# Altium schematic sheet Sch_Mcu.SchDoc
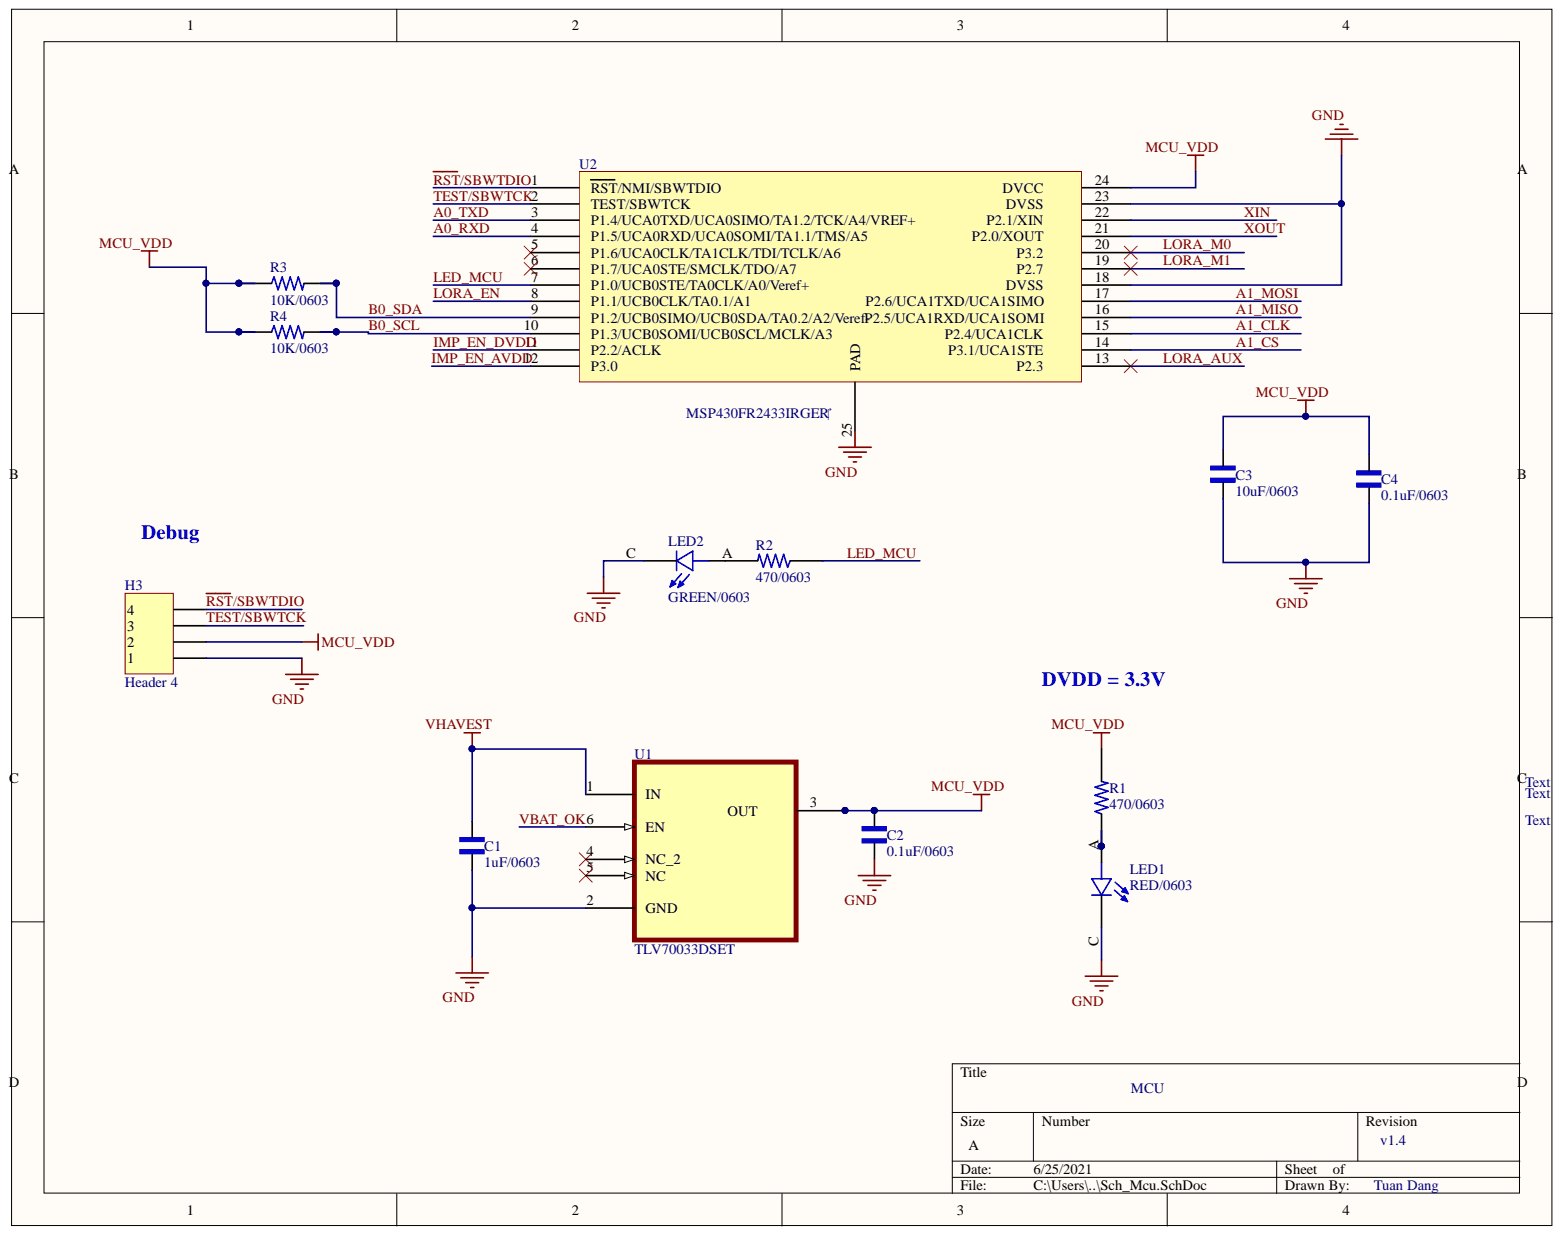
[diagram p0: Sch_Mcu.SchDoc]

## Parts
C1  1uF/0603  [CAP-0603]
C2  0.1uF/0603  [CAP-0603]
C3  10uF/0603  [CAP-0603]
C4  0.1uF/0603  [CAP-0603]
H3  Header 4  [HDR1X4]
LED1  RED/0603  [LED-0603]
LED2  GREEN/0603  [LED-0603]
R1  470/0603  [RES-0603]
R2  470/0603  [RES-0603]
R3  10K/0603  [RES-0603]
R4  10K/0603  [RES-0603]
U1  TLV70033DSET  [6WSON]
U2  MSP430FR2433IRGER‎  [VQFN-24]

## Nets
GND: C1.2 C2.1 C3.2 C4.2 H3.1 LED1.C LED2.C U1.2(GND) U2.18 U2.23 U2.25(PAD)
MCU_VDD: C2.2 C3.1 C4.1 H3.2 R1.2 R3.1 R4.1 U1.3 U2.24
VHAVEST: C1.1 U1.1(IN)
A0_RXD: U2.4(P1.5/UCA0RXD/UCA0SOMI/TA1.1/TMS/A5)
A0_TXD: U2.3(P1.4/UCA0TXD/UCA0SIMO/TA1.2/TCK/A4/VREF+)
A1_CLK: U2.15
A1_CS: U2.14
A1_MISO: U2.16
A1_MOSI: U2.17
B0_SCL: R4.2 U2.10(P1.3/UCB0SOMI/UCB0SCL/MCLK/A3)
B0_SDA: R3.2 U2.9(P1.2/UCB0SIMO/UCB0SDA/TA0.2/A2/Veref-)
IMP_EN_AVDD: U2.12(P3.0)
IMP_EN_DVDD: U2.11(P2.2/ACLK)
LED_MCU: R2.1 U2.7(P1.0/UCB0STE/TA0CLK/A0/Veref+)
LORA_AUX: U2.13
LORA_EN: U2.8(P1.1/UCB0CLK/TA0.1/A1)
LORA_M0: U2.20
LORA_M1: U2.19
~{RST}/SBWTDIO: H3.4 U2.1(RST/NMI/SBWTDIO)
TEST/SBWTCK: H3.3 U2.2(TEST/SBWTCK)
VBAT_OK: U1.6(EN)
XIN: U2.22
XOUT: U2.21
NetLED1_A: LED1.A R1.1
NetLED2_A: LED2.A R2.2

Not connected: U1 4(NC_2) 5(NC); U2 5(P1.6/UCA0CLK/TA1CLK/TDI/TCLK/A6) 6(P1.7/UCA0STE/SMCLK/TDO/A7)

## Library data of the parts
C1-C4 = Cap 0603: Ceramic Capacitor; MF: AVX; MP: LD031A330JAB2A_0; Availability: Unavailable; Component Kind: Standard; Package: 0603 AVX; Price: None; SnapEDA_Link: https://www.snapeda.com/parts/LD031A330JAB2A%5C500/AVX/view-part/2029942/?ref=snap; Library Name: tuandang.SchLib
H3 = Header 4: Header, 4-Pin; Component Kind: Standard; LatestRevisionDate: 17-Jul-2002; LatestRevisionNote: Re-released for DXP Platform.; Library Name: Miscellaneous Connectors.IntLib; Signal Integrity: Connector; Publisher: Altium Limited
LED1 LED2 = LED RED 0603: Component Kind: Standard; Library Name: tuandang.SchLib
R1-R4 = Resistor 0603: CRG0603 1% 4K75; MP: 1-1622960-0; Availability: Unavailable; Component Kind: Standard; Package: None; Price: None; Library Name: tuandang.SchLib
U2 = MSP430FR2433IRGER‎: Ma hang: MH29939; Component Kind: Standard; Library Name: tuandang.SchLib
U1 = TLV70033DSET: Component Kind: Standard; Library Name: tuandang.SchLib
